# Altium schematic sheet Microcontroller.SchDoc(Microcontroller)
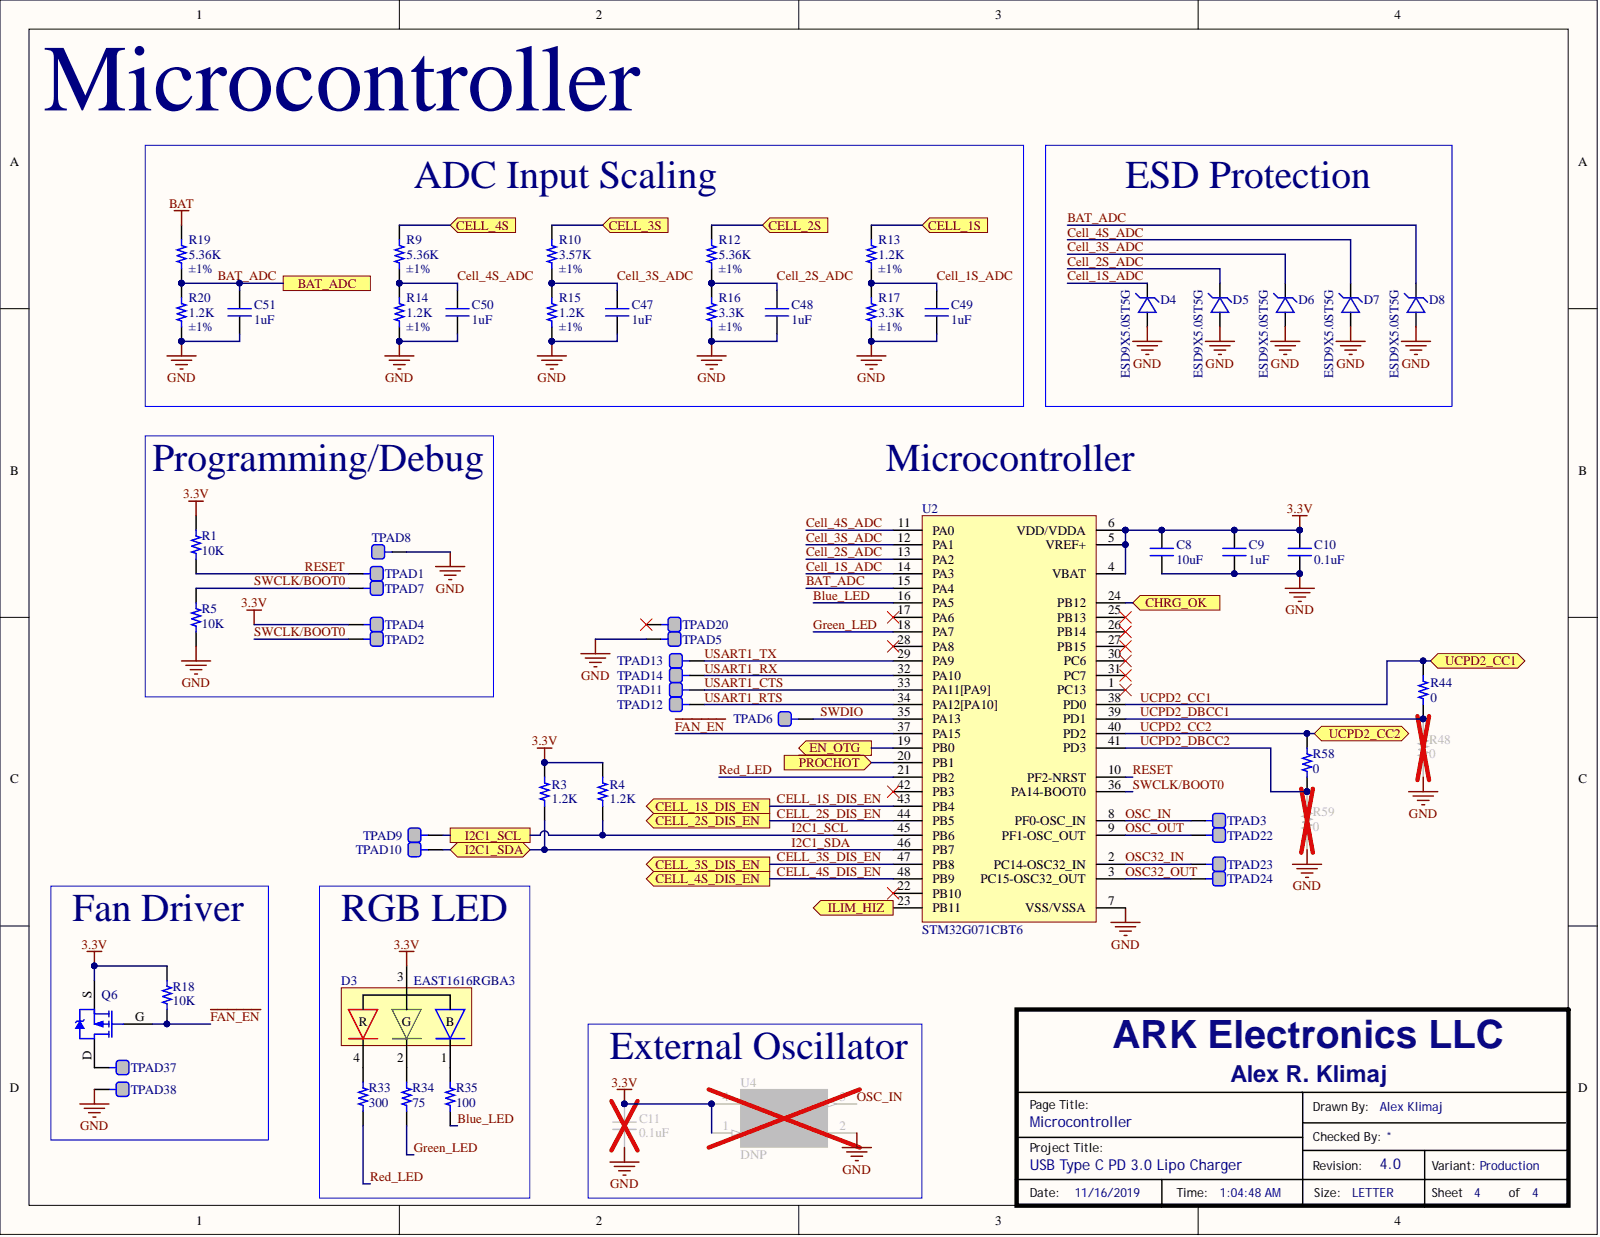
[diagram p0: Microcontroller.SchDoc]

## Parts
C8  10uF  [CAPC0805(2012)155_N]
C9  1uF  [CAPC0805(2012)155_N]
C10  0.1uF  [CAPC0805(2012)155_N]
C11  0.1uF  [CAPC0805(2012)155_N]
C47  1uF  [CAPC0805(2012)155_N]
C48  1uF  [CAPC0805(2012)155_N]
C49  1uF  [CAPC0805(2012)155_N]
C50  1uF  [CAPC0805(2012)155_N]
C51  1uF  [CAPC0805(2012)155_N]
D3  EAST1616RGBA3  [EAST1616RGBA3]
D4  ESD9X5.0ST5G  [SOD-923]
D5  ESD9X5.0ST5G  [SOD-923]
D6  ESD9X5.0ST5G  [SOD-923]
D7  ESD9X5.0ST5G  [SOD-923]
D8  ESD9X5.0ST5G  [SOD-923]
Q6  Vgs(max)=+-25V  [Diode SOT23 PMOS]  (value not drawn)
R1  10K  [RESC0805(2012)_L]
R3  1.2K  [RESC0805(2012)_L]
R4  1.2K  [RESC0805(2012)_L]
R5  10K  [RESC0805(2012)_L]
R9  5.36K  Tolerance: ±1%  [RESC0805(2012)_L]
R10  3.57K  Tolerance: ±1%  [RESC0805(2012)_L]
R12  5.36K  Tolerance: ±1%  [RESC0805(2012)_L]
R13  1.2K  Tolerance: ±1%  [RESC0805(2012)_L]
R14  1.2K  Tolerance: ±1%  [RESC0805(2012)_L]
R15  1.2K  Tolerance: ±1%  [RESC0805(2012)_L]
R16  3.3K  Tolerance: ±1%  [RESC0805(2012)_L]
R17  3.3K  Tolerance: ±1%  [RESC0805(2012)_L]
R18  10K  [RESC0805(2012)_L]
R19  5.36K  Tolerance: ±1%  [RESC0805(2012)_L]
R20  1.2K  Tolerance: ±1%  [RESC0805(2012)_L]
R33  300  [RESC0805(2012)_L]
R34  75  [RESC0805(2012)_L]
R35  100  [RESC0805(2012)_L]
R44  0  [RESC0805(2012)_L]
R48  0  [RESC0805(2012)_L]
R58  0  [RESC0805(2012)_L]
R59  0  [RESC0805(2012)_L]
TPAD1  TEST_PAD  [TEST_POINT]  (value not drawn)  (no BOM)
TPAD2  TEST_PAD  [TEST_POINT]  (value not drawn)  (no BOM)
TPAD3  TEST_PAD  [TEST_PAD]  (value not drawn)  (no BOM)
TPAD4  TEST_PAD  [TEST_POINT]  (value not drawn)  (no BOM)
TPAD5  TEST_PAD  [TEST_POINT]  (value not drawn)  (no BOM)
TPAD6  TEST_PAD  [TEST_POINT]  (value not drawn)  (no BOM)
TPAD7  TEST_PAD  [TEST_POINT]  (value not drawn)  (no BOM)
TPAD8  TEST_PAD  [TEST_POINT]  (value not drawn)  (no BOM)
TPAD9  TEST_PAD  [TEST_POINT]  (value not drawn)  (no BOM)
TPAD10  TEST_PAD  [TEST_POINT]  (value not drawn)  (no BOM)
TPAD11  TEST_PAD  [TEST_POINT]  (value not drawn)  (no BOM)
TPAD12  TEST_PAD  [TEST_POINT]  (value not drawn)  (no BOM)
TPAD13  TEST_PAD  [TEST_POINT]  (value not drawn)  (no BOM)
TPAD14  TEST_PAD  [TEST_POINT]  (value not drawn)  (no BOM)
TPAD20  TEST_PAD  [TEST_POINT]  (value not drawn)  (no BOM)
TPAD22  TEST_PAD  [TEST_PAD]  (value not drawn)  (no BOM)
TPAD23  TEST_PAD  [TEST_PAD]  (value not drawn)  (no BOM)
TPAD24  TEST_PAD  [TEST_PAD]  (value not drawn)  (no BOM)
TPAD37  TEST_PAD  [TEST_POINT]  (value not drawn)  (no BOM)
TPAD38  TEST_PAD  [TEST_POINT]  (value not drawn)  (no BOM)
U2  STM32G071CBT6  [ST LQFP48]
U4  DNP  [SiT8008BIA13-33E-8.000000D]

## Ports
BAT_ADC, CELL_1S, CELL_1S_DIS_EN, CELL_2S, CELL_2S_DIS_EN, CELL_3S, CELL_3S_DIS_EN, CELL_4S, CELL_4S_DIS_EN, CHRG_OK, EN_OTG, I2C1_SCL, I2C1_SDA, ILIM_HIZ, UCPD2_CC1, UCPD2_CC2, ~{PROCHOT}

## Nets
3.3V: C8.2 C9.2 C10.2 C11.2 D3.3 Q6.S R1.2 R3.2 R4.2 R18.2 TPAD4.1 U2.4(VBAT) U2.5(VREF+) U2.6(VDD/VDDA) U4.1(EN) U4.4(VDD)
BAT: R19.2
GND: C8.1 C9.1 C10.1 C11.1 C47.1 C48.1 C49.1 C50.1 C51.1 D4.2 D5.2 D6.2 D7.2 D8.2 R5.1 R14.1 R15.1 R16.1 R17.1 R20.1 R48.1 R59.1 TPAD5.1 TPAD8.1 TPAD38.1 U2.7(VSS/VSSA) U4.2(GND)
BAT_ADC: C51.2 D8.1 R19.1 R20.2 U2.15(PA4) ; port BAT_ADC
Blue_LED: R35.1 U2.16(PA5)
CELL_1S: R13.2 ; port CELL_1S
Cell_1S_ADC: C49.2 D4.1 R13.1 R17.2 U2.14(PA3) U2.43(PB4) U2.44(PB5) U2.47(PB8) U2.48(PB9) ; port CELL_1S_DIS_EN, port CELL_2S_DIS_EN, port CELL_3S_DIS_EN, port CELL_4S_DIS_EN  [also labelled CELL_1S_DIS_EN, CELL_2S_DIS_EN, CELL_3S_DIS_EN, CELL_4S_DIS_EN]
CELL_2S: R12.2 ; port CELL_2S
Cell_2S_ADC: C48.2 D5.1 R12.1 R16.2 U2.13(PA2)
CELL_3S: R10.2 ; port CELL_3S
Cell_3S_ADC: C47.2 D6.1 R10.1 R15.2 U2.12(PA1)
CELL_4S: R9.2 ; port CELL_4S
Cell_4S_ADC: C50.2 D7.1 R9.1 R14.2 U2.11(PA0)
CHRG_OK: U2.24(PB12) ; port CHRG_OK
EN_OTG: U2.19(PB0) ; port EN_OTG
~{FAN_EN}: Q6.G R18.1 U2.37(PA15)
Green_LED: R34.1 U2.18(PA7)
I2C1_SCL: R4.1 TPAD9.1 U2.45(PB6) ; port I2C1_SCL
I2C1_SDA: R3.1 TPAD10.1 U2.46(PB7) ; port I2C1_SDA
ILIM_HIZ: U2.23(PB11) ; port ILIM_HIZ
OSC32_IN: TPAD23.1 U2.2(PC14-OSC32_IN)
OSC32_OUT: TPAD24.1 U2.3(PC15-OSC32_OUT)
OSC_IN: TPAD3.1 U2.8(PF0-OSC_IN) U4.3(OUT)
OSC_OUT: TPAD22.1 U2.9(PF1-OSC_OUT)
~{PROCHOT}: U2.20(PB1) ; port ~{PROCHOT}
Red_LED: R33.1 U2.21(PB2)
RESET: R1.1 TPAD1.1 U2.10(PF2-NRST)
SWCLK/BOOT0: R5.2 TPAD2.1 TPAD7.1 U2.36(PA14-BOOT0)
SWDIO: TPAD6.1 U2.35(PA13)
UCPD2_CC1: R44.2 U2.38(PD0) ; port UCPD2_CC1
UCPD2_CC2: R58.2 U2.40(PD2) ; port UCPD2_CC2
UCPD2_DBCC1: R44.1 R48.2 U2.39(PD1)
UCPD2_DBCC2: R58.1 R59.2 U2.41(PD3)
USART1_CTS: TPAD11.1 U2.33(PA11[PA9])
USART1_RTS: TPAD12.1 U2.34(PA12[PA10])
USART1_RX: TPAD14.1 U2.32(PA10)
USART1_TX: TPAD13.1 U2.29(PA9)
NetD3_1: D3.1(B) R35.2
NetD3_2: D3.2(G) R34.2
NetD3_4: D3.4(R) R33.2
NetQ6_D: Q6.D TPAD37.1

Not connected: TPAD20 1; U2 1(PC13) 17(PA6) 22(PB10) 25(PB13) 26(PB14) 27(PB15) 28(PA8) 30(PC6) 31(PC7) 42(PB3)

## Library data of the parts
C8 = 30220: SMT Capacitor; Mfr Part Number: C2012X5R1V106M085AC; Mfr Part Description: CAP CER 10uF ±20% 35V X5R 0805 (2012 Metric); Manufacturer: TDK Corporation; Voltage_Rating: 35V; Updated By: aklimaj; Tolerance: ±20%; Dielectric: X5R; Database Table Name: Parts; Value: 10uF; Updated_Date: 2018/10/19 21:37:43; Temperature Range: -55C to +85C; Supplier Part Number: 445-14419-1-ND; Supplier: Digi-Key; RoHS: Yes; Part_Type: Capacitor; Package_Case: 0805 (2012 Metric); LifeCycle: New; Library Name: Parts.DbLib; ID: 30220; Created_Date: 2018/10/14 19:21:49; ComponentLink2URL: https://www.digikey.com/product-detail/en/tdk-corporation/C2012X5R1V106M085AC/445-14419-1-ND/3956085; ComponentLink2Description: Supplier Part Details; ComponentLink1URL: https://product.tdk.com/info/en/catalog/datasheets/mlcc_commercial_general_en.pdf; ComponentLink1Description: Datasheet; Component Kind: Standard; Created By: aklimaj
C9 C47-C51 = 30222: SMT Capacitor; Mfr Part Number: CL21B105KBFNNNG; Mfr Part Description: CAP CER 1uF ±10% 50V X7R 0805 (2012 Metric); Manufacturer: Samsung Electro-Mechanics; Voltage_Rating: 50V; Updated By: aklimaj; Tolerance: ±10%; Dielectric: X7R; Database Table Name: Parts; Value: 1uF; Updated_Date: 2018/10/19 21:37:42; Temperature Range: -55C to +125C; Supplier Part Number: 1276-6470-1-ND; Supplier: Digi-Key; RoHS: Yes; Part_Type: Capacitor; Package_Case: 0805 (2012 Metric); LifeCycle: New; Library Name: Parts.DbLib; ID: 30222; Created_Date: 2018/10/14 19:30:56; ComponentLink2URL: https://www.digikey.com/product-detail/en/samsung-electro-mechanics/CL21B105KBFNNNG/1276-6470-1-ND/5958098; ComponentLink2Description: Supplier Part Details; ComponentLink1URL: http://www.samsungsem.com/kr/support/product-search/mlcc/CL21B105KBFNNNG.jsp; ComponentLink1Description: Datasheet; Component Kind: Standard; Created By: aklimaj
C10 C11 = 30221: SMT Capacitor; Mfr Part Number: CL21B104KCFNNNE; Mfr Part Description: CAP CER 0.1uF ±10% 100V X7R 0805 (2012 Metric); Manufacturer: Samsung Electro-Mechanics; Voltage_Rating: 100V; Updated By: aklimaj; Tolerance: ±10%; Dielectric: X7R; Database Table Name: Parts; Value: 0.1uF; Updated_Date: 2018/10/19 21:37:43; Temperature Range: -55C to +125C; Supplier Part Number: 1276-6840-1-ND; Supplier: Digi-Key; RoHS: Yes; Part_Type: Capacitor; Package_Case: 0805 (2012 Metric); LifeCycle: New; Library Name: Parts.DbLib; ID: 30221; Created_Date: 2018/10/14 19:28:20; ComponentLink2URL: https://www.digikey.com/product-detail/en/samsung-electro-mechanics/CL21B104KCFNNNE/1276-6840-1-ND/5961699; ComponentLink2Description: Supplier Part Details; ComponentLink1URL: http://www.samsungsem.com/kr/support/product-search/mlcc/CL21B104KCFNNNE.jsp; ComponentLink1Description: Datasheet; Component Kind: Standard; Created By: aklimaj
D3 = 30242: Part_Type: Discrete; Mfr Part Description: LED RGB CLEAR 4SMD; Manufacturer: Everlight Electronics Co Ltd; Voltage_Rating: 1.95V Red, 2.8V Green, 2.8V Blue; Updated By: aklimaj; Database Table Name: Parts; Current_Rating: 5mA Red, 5mA Green, 5mA Blue; Value: EAST1616RGBA3; Updated_Date: 2018/11/03 21:20:43; Supplier Part Number: 1080-1550-1-ND; Supplier: Digi-Key; RoHS: Yes; Package_Case: 0606 (1616 Metric); Mfr Part Number: EAST1616RGBA3; LifeCycle: New; Library Name: Parts.DbLib; ID: 30242; Created_Date: 2018/11/03 21:02:13; ComponentLink2URL: https://www.digikey.com/product-detail/en/everlight-electronics-co-ltd/EAST1616RGBA3/1080-1550-1-ND/8510378; ComponentLink2Description: Supplier Part Details; ComponentLink1URL: https://everlightamericas.com/index.php?controller=attachment&id_attachment=1010; ComponentLink1Description: Datasheet; Component Kind: Standard; Created By: aklimaj
D4-D8 = 30239: TVS Diode; Part_Type: Protection; Mfr Part Description: TVS DIODE 5V 12.3V SOD923; Manufacturer: ON Semiconductor; Updated By: aklimaj; Database Table Name: Parts; Current_Rating: 8.7A (8/20µs); Value: ESD9X5.0ST5G; Updated_Date: 2018/11/03 11:39:36; Temperature Range: -55C to +150C (TJ); Supplier Part Number: ESD9X5.0ST5GOSCT-ND; Supplier: Digi-Key; RoHS: Yes; Package_Case: SOD-923; Mfr Part Number: ESD9X5.0ST5G; LifeCycle: New; Library Name: Parts.DbLib; ID: 30239; Created_Date: 2018/11/03 11:31:29; ComponentLink2URL: https://www.digikey.com/product-detail/en/on-semiconductor/ESD9X5.0ST5G/ESD9X5.0ST5GOSCT-ND/2120624; ComponentLink2Description: Supplier Part Details; ComponentLink1URL: http://www.onsemi.com/pub/Collateral/ESD9X3.3ST5G-D.PDF; ComponentLink1Description: Datasheet; Component Kind: Standard; Created By: aklimaj
Q6 = 30266: Part_Type: Discrete; Mfr Part Description: MOSFET P-CH 30V 4.3A SOT23; Manufacturer: Diodes Incorporated; Voltage_Rating: 30V; Value: DMP3056L-7; Updated By: aklimaj; Database Table Name: Parts; Current_Rating: 4.3A (Ta); Updated_Date: 2018/11/08 12:54:41; Temperature Range: -55C to +150C (TJ); Supplier Part Number: DMP3056L-7DICT-ND; Supplier: Digi-Key; RoHS: Yes; Package_Case: TO-236-3, SC-59, SOT-23-3; Mfr Part Number: DMP3056L-7; LifeCycle: New; Library Name: Parts.DbLib; ID: 30266; Created_Date: 2018/11/08 12:42:57; ComponentLink2URL: https://www.digikey.com/product-detail/en/diodes-incorporated/DMP3056L-7/DMP3056L-7DICT-ND/5126873; ComponentLink2Description: Supplier Part Details; ComponentLink1URL: https://www.diodes.com/assets/Datasheets/DMP3056L.pdf; ComponentLink1Description: Datasheet; Component Kind: Standard; Created By: aklimaj
R1 R5 R18 = 30227: SMT Resistor; Mfr Part Number: CR0805-FX-1002ELF; Mfr Part Description: RES 10K Ohm ±1% 1/8W 0805 (2012 Metric); Manufacturer: Bourns Inc.; Updated By: aklimaj; Tolerance: ±1%; Temperature Coeff: ±100ppm/degC; Power_Rating: 0.125W, 1/8W; Database Table Name: Parts; Value: 10K; Updated_Date: 2018/10/19 21:37:37; Temperature Range: -55C to +155C; Supplier Part Number: CR0805-FX-1002ELFCT-ND; Supplier: Digi-Key; RoHS: Yes; Part_Type: Resistor; Package_Case: 0805 (2012 Metric); LifeCycle: New; Library Name: Parts.DbLib; ID: 30227; Created_Date: 2018/10/16 22:16:46; ComponentLink2URL: https://www.digikey.com/product-detail/en/bourns-inc/CR0805-FX-1002ELF/CR0805-FX-1002ELFCT-ND/3592928; ComponentLink2Description: Supplier Part Details; ComponentLink1URL: https://www.bourns.com/docs/Product-Datasheets/CHPREZTR.pdf; ComponentLink1Description: Datasheet; Component Kind: Standard; Created By: aklimaj
R3 R4 = 30236: SMT Resistor; Mfr Part Number: CRGCQ0805F1K2; Mfr Part Description: RES 1.2K Ohm ±1% 1/8W 0805 (2012 Metric); Manufacturer: TE Connectivity Passive Product; Updated By: aklimaj; Tolerance: ±1%; Temperature Coeff: ±100ppm/degC; Power_Rating: 0.125W, 1/8W; Database Table Name: Parts; Value: 1.2K; Updated_Date: 2018/11/02 19:33:50; Temperature Range: -55C to +155C; Supplier Part Number: A129750CT-ND; Supplier: Digi-Key; RoHS: Yes; Part_Type: Resistor; Package_Case: 0805 (2012 Metric); LifeCycle: New; Library Name: Parts.DbLib; ID: 30236; Created_Date: 2018/11/02 19:33:38; ComponentLink2URL: https://www.digikey.com/product-detail/en/te-connectivity-passive-product/CRGCQ0805F1K2/A129750CT-ND/8577582; ComponentLink2Description: Supplier Part Details; ComponentLink1URL: https://www.te.com/commerce/DocumentDelivery/DDEController?Action=srchrtrv&DocNm=1773204-3&DocType=DS&DocLang=English; ComponentLink1Description: Datasheet; Component Kind: Standard; Created By: aklimaj
R9 R12 R19 = 30294: SMT Resistor; Mfr Part Number: RMCF0805FG5K36; Mfr Part Description: RES 5.36K Ohm ±1% 1/8W 0805 (2012 Metric); Manufacturer: Stackpole Electronics Inc.; Updated By: aklimaj; Temperature Coeff: ±100ppm/degC; Power_Rating: 0.125W, 1/8W; Database Table Name: Parts; Value: 5.36K; Updated_Date: 2019/02/03 16:55:12; Temperature Range: -55C to +155C; Supplier Part Number: RMCF0805FG5K36CT-ND; Supplier: Digi-Key; RoHS: Yes; Part_Type: Resistor; Package_Case: 0805 (2012 Metric); LifeCycle: New; Library Name: Parts.DbLib; ID: 30294; Created_Date: 2019/02/03 16:54:53; ComponentLink2URL: https://www.digikey.com/product-detail/en/stackpole-electronics-inc/RMCF0805FG5K36/RMCF0805FG5K36CT-ND/6052980; ComponentLink2Description: Supplier Part Details; ComponentLink1URL: https://www.seielect.com/Catalog/SEI-RMCF_RMCP.pdf; ComponentLink1Description: Datasheet; Component Kind: Standard; Created By: aklimaj
R10 = 30295: SMT Resistor; Mfr Part Number: RMCF0805FT3K57; Mfr Part Description: RES 3.57K Ohm ±1% 1/8W 0805 (2012 Metric); Manufacturer: Stackpole Electronics Inc.; Updated By: aklimaj; Temperature Coeff: ±100ppm/degC; Power_Rating: 0.125W, 1/8W; Database Table Name: Parts; Value: 3.57K; Updated_Date: 2019/02/03 17:04:23; Temperature Range: -55C to +155C; Supplier Part Number: RMCF0805FT3K57CT-ND; Supplier: Digi-Key; RoHS: Yes; Part_Type: Resistor; Package_Case: 0805 (2012 Metric); LifeCycle: New; Library Name: Parts.DbLib; ID: 30295; Created_Date: 2019/02/03 17:04:07; ComponentLink2URL: https://www.digikey.com/product-detail/en/stackpole-electronics-inc/RMCF0805FT3K57/RMCF0805FT3K57CT-ND/1942402; ComponentLink2Description: Supplier Part Details; ComponentLink1URL: https://www.seielect.com/Catalog/SEI-RMCF_RMCP.pdf; ComponentLink1Description: Datasheet; Component Kind: Standard; Created By: aklimaj
R13-R15 R20 = 30236: SMT Resistor; Mfr Part Number: CRGCQ0805F1K2; Mfr Part Description: RES 1.2K Ohm ±1% 1/8W 0805 (2012 Metric); Manufacturer: TE Connectivity Passive Product; Updated By: aklimaj; Temperature Coeff: ±100ppm/degC; Power_Rating: 0.125W, 1/8W; Database Table Name: Parts; Value: 1.2K; Updated_Date: 2018/11/02 19:33:50; Temperature Range: -55C to +155C; Supplier Part Number: A129750CT-ND; Supplier: Digi-Key; RoHS: Yes; Part_Type: Resistor; Package_Case: 0805 (2012 Metric); LifeCycle: New; Library Name: Parts.DbLib; ID: 30236; Created_Date: 2018/11/02 19:33:38; ComponentLink2URL: https://www.digikey.com/product-detail/en/te-connectivity-passive-product/CRGCQ0805F1K2/A129750CT-ND/8577582; ComponentLink2Description: Supplier Part Details; ComponentLink1URL: https://www.te.com/commerce/DocumentDelivery/DDEController?Action=srchrtrv&DocNm=1773204-3&DocType=DS&DocLang=English; ComponentLink1Description: Datasheet; Component Kind: Standard; Created By: aklimaj
R16 R17 = 30235: SMT Resistor; Mfr Part Number: RMCF0805FT3K30; Mfr Part Description: RES 3.3K Ohm ±1% 1/8W 0805 (2012 Metric); Manufacturer: Stackpole Electronics Inc.; Updated By: aklimaj; Temperature Coeff: ±100ppm/degC; Power_Rating: 0.125W, 1/8W; Database Table Name: Parts; Value: 3.3K; Updated_Date: 2018/11/02 19:31:08; Temperature Range: -55C to +155C; Supplier Part Number: RMCF0805FT3K30CT-ND; Supplier: Digi-Key; RoHS: Yes; Part_Type: Resistor; Package_Case: 0805 (2012 Metric); LifeCycle: New; Library Name: Parts.DbLib; ID: 30235; Created_Date: 2018/11/02 19:30:31; ComponentLink2URL: https://www.digikey.com/product-detail/en/stackpole-electronics-inc/RMCF0805FT3K30/RMCF0805FT3K30CT-ND/1942399; ComponentLink2Description: Supplier Part Details; ComponentLink1URL: https://www.seielect.com/Catalog/SEI-RMCF_RMCP.pdf; ComponentLink1Description: Datasheet; Component Kind: Standard; Created By: aklimaj
R33 = 30243: SMT Resistor; Mfr Part Number: RMCF0805JT300R; Mfr Part Description: RES 300 Ohm ±5% 1/8W 0805 (2012 Metric); Manufacturer: Stackpole Electronics Inc.; Updated By: aklimaj; Tolerance: ±5%; Temperature Coeff: ±200ppm/degC; Power_Rating: 0.125W, 1/8W; Database Table Name: Parts; Value: 300; Updated_Date: 2018/11/03 21:24:27; Temperature Range: -55C to +155C; Supplier Part Number: RMCF0805JT300RCT-ND; Supplier: Digi-Key; RoHS: Yes; Part_Type: Resistor; Package_Case: 0805 (2012 Metric); LifeCycle: New; Library Name: Parts.DbLib; ID: 30243; Created_Date: 2018/11/03 21:24:11; ComponentLink2URL: https://www.digikey.com/product-detail/en/stackpole-electronics-inc/RMCF0805JT300R/RMCF0805JT300RCT-ND/5049826; ComponentLink2Description: Supplier Part Details; ComponentLink1URL: https://www.seielect.com/Catalog/SEI-RMCF_RMCP.pdf; ComponentLink1Description: Datasheet; Component Kind: Standard; Created By: aklimaj
R34 = 30244: SMT Resistor; Mfr Part Number: RMCF0805JT75R0; Mfr Part Description: RES 75 Ohm ±5% 1/8W 0805 (2012 Metric); Manufacturer: Stackpole Electronics Inc.; Updated By: aklimaj; Tolerance: ±5%; Temperature Coeff: ±200ppm/degC; Power_Rating: 0.125W, 1/8W; Database Table Name: Parts; Value: 75; Updated_Date: 2018/11/03 21:30:43; Temperature Range: -55C to +155C; Supplier Part Number: RMCF0805JT75R0CT-ND; Supplier: Digi-Key; RoHS: Yes; Part_Type: Resistor; Package_Case: 0805 (2012 Metric); LifeCycle: New; Library Name: Parts.DbLib; ID: 30244; Created_Date: 2018/11/03 21:30:27; ComponentLink2URL: https://www.digikey.com/product-detail/en/stackpole-electronics-inc/RMCF0805JT75R0/RMCF0805JT75R0CT-ND/1942538; ComponentLink2Description: Supplier Part Details; ComponentLink1URL: https://www.seielect.com/Catalog/SEI-RMCF_RMCP.pdf; ComponentLink1Description: Datasheet; Component Kind: Standard; Created By: aklimaj
R35 = 30245: SMT Resistor; Mfr Part Number: CR0805-JW-101ELF; Mfr Part Description: RES 100 Ohm ±5% 1/8W 0805 (2012 Metric); Manufacturer: Bourns Inc.; Updated By: aklimaj; Tolerance: ±5%; Temperature Coeff: ±200ppm/degC; Power_Rating: 0.125W, 1/8W; Database Table Name: Parts; Value: 100; Updated_Date: 2018/11/03 21:47:28; Temperature Range: -55C to +155C; Supplier Part Number: CR0805-JW-101ELFCT-ND; Supplier: Digi-Key; RoHS: Yes; Part_Type: Resistor; Package_Case: 0805 (2012 Metric); LifeCycle: New; Library Name: Parts.DbLib; ID: 30245; Created_Date: 2018/11/03 21:31:47; ComponentLink2URL: https://www.digikey.com/product-detail/en/bourns-inc/CR0805-JW-101ELF/CR0805-JW-101ELFCT-ND/3741013; ComponentLink2Description: Supplier Part Details; ComponentLink1URL: https://www.bourns.com/docs/Product-Datasheets/CHPREZTR.pdf; ComponentLink1Description: Datasheet; Component Kind: Standard; Created By: aklimaj
R44 R48 R58 R59 = 30238: SMT Resistor; Mfr Part Number: CR0805-J/-000ELF; Mfr Part Description: RES 0 Ohm Jumper 1/8W 0805 (2012 Metric); Manufacturer: Bourns Inc.; Voltage_Rating: 150V; Updated By: aklimaj; Tolerance: Jumper; Power_Rating: 0.125W, 1/8W; Database Table Name: Parts; Current_Rating: 2A; Value: 0; Updated_Date: 2018/11/02 22:20:59; Temperature Range: -55C to +155C; Supplier Part Number: CR0805-J/-000ELFCT-ND; Supplier: Digi-Key; RoHS: Yes; Part_Type: Resistor; Package_Case: 0805 (2012 Metric); LifeCycle: New; Library Name: Parts.DbLib; ID: 30238; Created_Date: 2018/11/02 22:19:43; ComponentLink2URL: https://www.digikey.com/product-detail/en/bourns-inc/CR0805-J-000ELF/CR0805-J-000ELFCT-ND/3592913; ComponentLink2Description: Supplier Part Details; ComponentLink1URL: https://www.bourns.com/docs/Product-Datasheets/CHPREZTR.pdf; ComponentLink1Description: Datasheet; Component Kind: Standard; Created By: aklimaj
TPAD1-TPAD14 TPAD20 TPAD22-TPAD24 TPAD37 TPAD38 = 30015: Part_Type: Test Point; Updated By: aklimaj; Database Table Name: Parts; Updated_Date: 2017/07/13 09:29:11; RoHS: Yes; Mfr Part Description: Test Point; LifeCycle: New; Library Name: Parts.DbLib; ID: 30015; Description: TEST_PAD; Created_Date: 2017/07/13 09:26:35; ComponentLink2Description: Supplier Part Details; ComponentLink1Description: Datasheet; Created By: aklimaj
U2 = 30269: Part_Type: IC; Mfr Part Description: MAINSTREAM ARM CORTEX-M0+ MCU WI; Manufacturer: STMicroelectronics; Updated By: aklimaj; Sub_Type: ARM; Database Table Name: Parts; Value: STM32G071CBT6; Updated_Date: 2018/12/06 11:10:55; Temperature Range: -40C to +85C (TA); Supplier Part Number: 497-18342-ND; Supplier: Digi-Key; RoHS: Yes; Package_Case: 48-LQFP; Mfr Part Number: STM32G071CBT6; LifeCycle: New; Library Name: Parts.DbLib; ID: 30269; Created_Date: 2018/12/06 10:20:31; ComponentLink2URL: https://www.digikey.com/product-detail/en/stmicroelectronics/STM32G071CBT6/497-18342-ND/9749147; ComponentLink2Description: Supplier Part Details; ComponentLink1URL: https://media.digikey.com/pdf/Data%20Sheets/ST%20Microelectronics%20PDFS/STM32G071_Rev1_DS.pdf; ComponentLink1Description: Datasheet; Component Kind: Standard; Created By: aklimaj
U4 = 30183: Teal Part Number: 20476; Part_Type: OSC; Mfr Part Description: OSC MEMS 24.000MHZ CMOS SMD; Manufacturer: Abracon; Voltage_Rating: 3.3V; Value: ASDM1-24.000MHZ-LC-T; Updated By: aklimaj; Notes: SiT8008BI-13-33E-24.000000E; Database Table Name: Parts; Current_Rating: 10mA; Updated_Date: 2018/10/19 21:38:08; Temperature Range: -40C to +85C; Supplier Part Number: 535-9790-1-ND; Supplier: Digi-Key; RoHS: Yes; Package_Case: 4-SMD, No Lead; Mfr Part Number: ASDM1-24.000MHZ-LC-T; LifeCycle: New; Library Name: Parts.DbLib; ID: 30183; Created_Date: 2018/04/04 15:16:38; ComponentLink2URL: https://www.digikey.com/product-detail/en/abracon-llc/ASDM1-24.000MHZ-LC-T/535-9790-1-ND/1873324; ComponentLink2Description: Supplier Part Details; ComponentLink1URL: http://www.abracon.com/Oscillators/ASDM.pdf; ComponentLink1Description: Datasheet; Component Kind: Standard; Created By: aklimaj
